# Altium schematic sheet PowerSchematic.SchDoc(PowerSchematic)
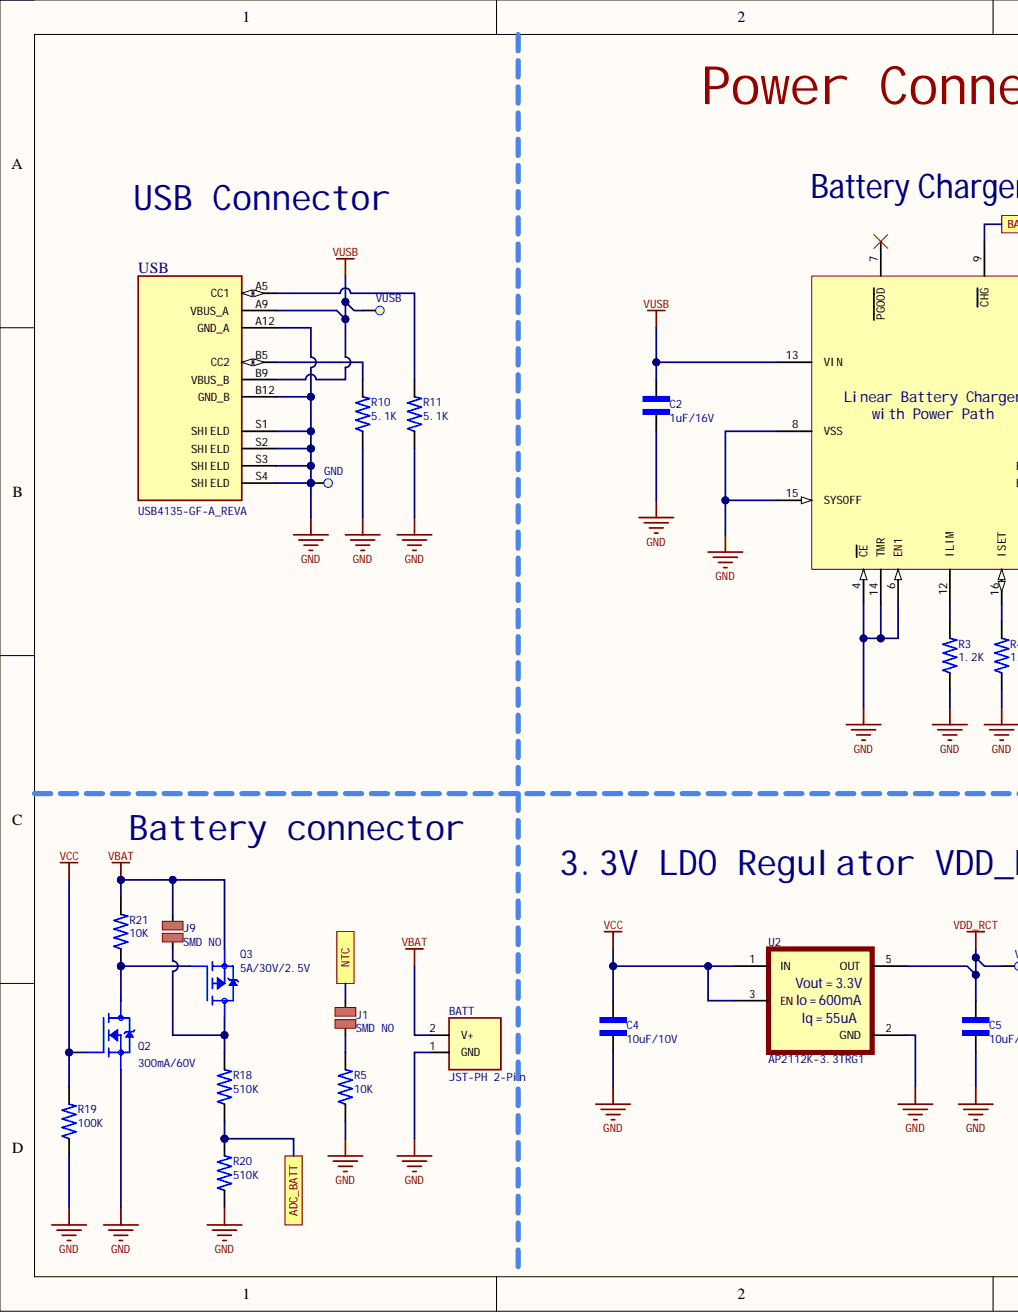
[diagram p0: PowerSchematic.SchDoc, left]
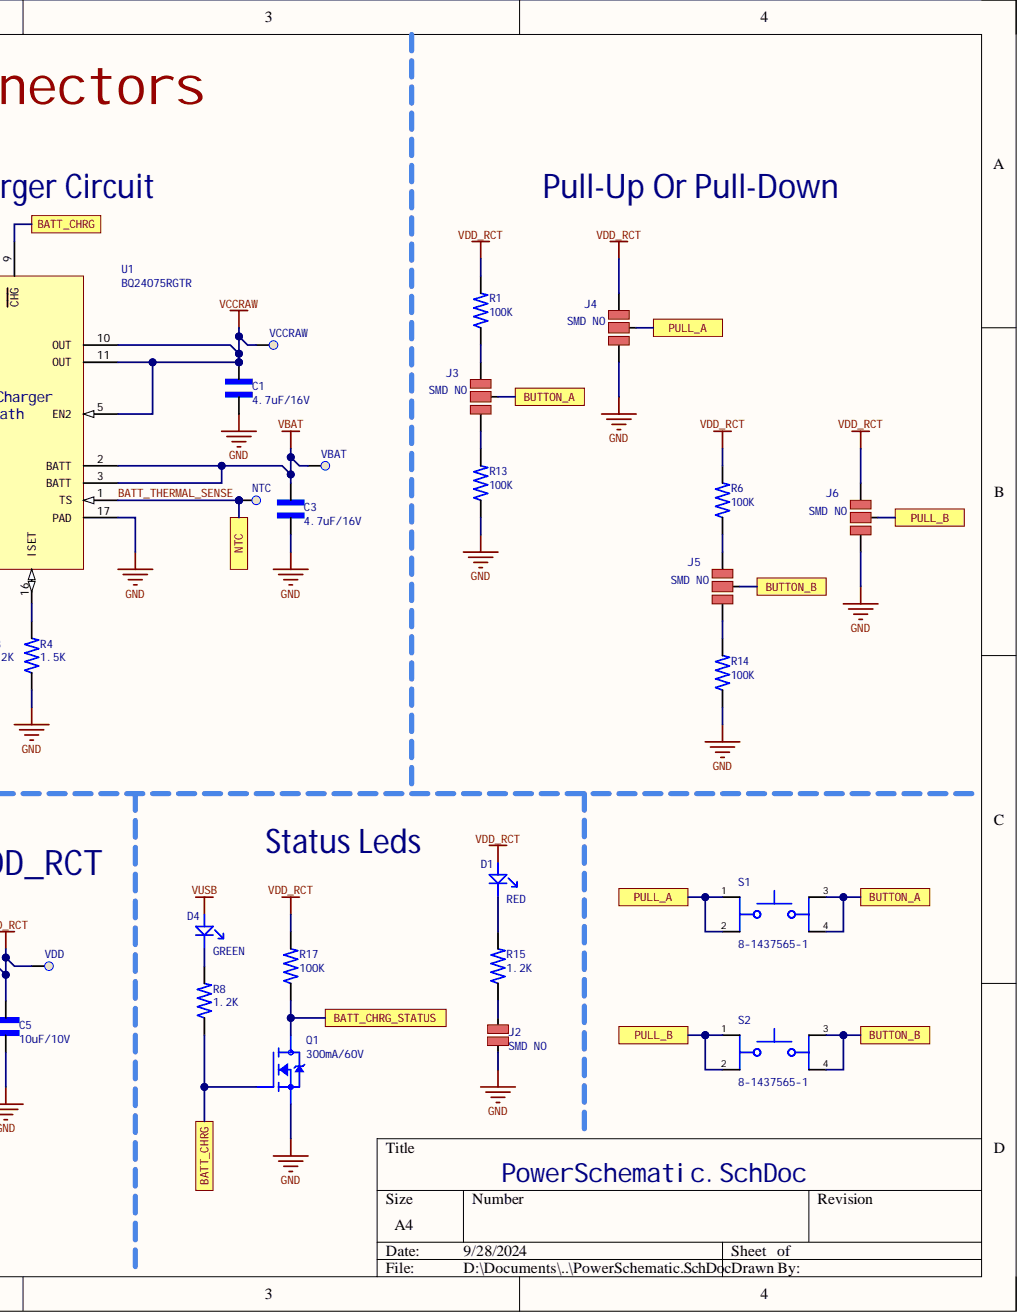
[diagram p1: PowerSchematic.SchDoc, right]

## Parts
BATT  JST-PH 2-Pin  [JST_B2B-PH-K-S(LF)(SN)]
C1  4.7uF/16V  [C0603]
C2  1uF/16V  [C0603]
C3  4.7uF/16V  [C0603]
C4  10uF/10V  [C0603]
C5  10uF/10V  [C0603]
D1  RED  [LED 0603]
D4  GREEN  [LED 0603]
GND  Test point 1.2mm  [TEST_POINT_1.2MM]  (value not drawn)  (no BOM)
J1  SMD NO  [JUMPER_SMD_NO]
J2  SMD NO  [JUMPER_SMD_NO]
J3  SMD NO  [JUMPER_SMD_NO_2WAY]
J4  SMD NO  [JUMPER_SMD_NO_2WAY]
J5  SMD NO  [JUMPER_SMD_NO_2WAY]
J6  SMD NO  [JUMPER_SMD_NO_2WAY]
J9  SMD NO  [JUMPER_SMD_NO]
NTC  Test point 1.2mm  [TEST_POINT_1.2MM]  (value not drawn)  (no BOM)
Q1  300mA/60V  [SOT-23-3]
Q2  300mA/60V  [SOT-23-3]
Q3  5A/30V/2.5V  [SOT-23-3]
R1  100K  [R0603]
R3  1.2K  [R0603]
R4  1.5K  [R0603]
R5  10K  [R0603]
R6  100K  [R0603]
R8  1.2K  [R0603]
R10  5.1K  [R0603]
R11  5.1K  [R0603]
R13  100K  [R0603]
R14  100K  [R0603]
R15  1.2K  [R0603]
R17  100K  [R0603]
R18  510K  [R0603]
R19  100K  [R0603]
R20  510K  [R0603]
R21  10K  [R0603]
S1  8-1437565-1  [SW_8-1437565-1]
S2  8-1437565-1  [SW_8-1437565-1]
U1  BQ24075RGTR  [VQFN16]
U2  AP2112K-3.3TRG1  Max Output Current: 600mA  Output Voltage: 3.3V  Quiescent Current: 55uA  [SOT-25-5]
USB  USB4135-GF-A_REVA  [GCT_USB4135 16-pin]
VBAT  Test point 1.2mm  [TEST_POINT_1.2MM]  (value not drawn)  (no BOM)
VCCRAW  Test point 1.2mm  [TEST_POINT_1.2MM]  (value not drawn)  (no BOM)
VDD  Test point 1.2mm  [TEST_POINT_1.2MM]  (value not drawn)  (no BOM)
VUSB  Test point 1.2mm  [TEST_POINT_1.2MM]  (value not drawn)  (no BOM)

## Ports
ADC_BATT, BATT_CHRG, BATT_CHRG_STATUS, BUTTON_A, BUTTON_B, NTC, PULL_A, PULL_B

## Nets
GND: BATT.1 C1.2 C2.2 C3.2 C4.2 C5.2 GND.1 J2.2 J4.1 J6.1 Q1.2 Q2.2 R3.1 R4.1 R5.1 R10.1 R11.1 R13.1 R14.1 R19.1 R20.1 U1.4(CE) U1.6(EN1) U1.8(VSS) U1.14(TMR) U1.15(SYSOFF) U1.17(PAD) U2.2(GND) USB.A12(GND_A) USB.B12(GND_B) USB.S1(SHIELD) USB.S2(SHIELD) USB.S3(SHIELD) USB.S4(SHIELD)
VBAT: BATT.2 C3.1 J9.1 Q3.2 R21.2 U1.2(BATT) U1.3(BATT) VBAT.1
VCC: C4.1 Q2.1 R19.2 U2.1(IN) U2.3(EN)
VCCRAW: C1.1 U1.5(EN2) U1.10(OUT) U1.11(OUT) VCCRAW.1
VDD_RCT: C5.1 D1.1 J4.3 J6.3 R1.2 R6.2 R17.2 U2.5(OUT) VDD.1
VUSB: C2.1 D4.1 U1.13(VIN) USB.A9(VBUS_A) USB.B9(VBUS_B) VUSB.1
ADC_BATT: R18.1 R20.2 ; port ADC_BATT
BATT_CHRG: Q1.1 R8.1 U1.9(CHG) ; port BATT_CHRG
BATT_CHRG_STATUS: Q1.3 R17.1 ; port BATT_CHRG_STATUS
BATT_THERMAL_SENSE: J1.1 NTC.1 U1.1(TS) ; port NTC
BUTTON_A: J3.2 S1.3 S1.4 ; port BUTTON_A
BUTTON_B: J5.2 S2.3 S2.4 ; port BUTTON_B
PULL_A: J4.2 S1.1 S1.2 ; port PULL_A
PULL_B: J6.2 S2.1 S2.2 ; port PULL_B
NetD1_2: D1.2 R15.2
NetD4_2: D4.2 R8.2
NetJ1_2: J1.2 R5.2
NetJ2_1: J2.1 R15.1
NetJ3_1: J3.1 R13.2
NetJ3_3: J3.3 R1.1
NetJ5_1: J5.1 R14.2
NetJ5_3: J5.3 R6.1
NetJ9_2: J9.2 Q3.3 R18.2
NetQ2_3: Q2.3 Q3.1 R21.1
NetR3_2: R3.2 U1.12(ILIM)
NetR4_2: R4.2 U1.16(ISET)
NetR10_2: R10.2 USB.B5(CC2)
NetR11_2: R11.2 USB.A5(CC1)

Not connected: U1 7(PGOOD)

## Library data of the parts
BATT = JST-PH 2-Pin: JST (JAPAN SOLDERLESS TERMINALS) - B2B-PH-K-S(LF)(SN) - Pin Header, Top Entry, Wire-to-Board, 2 mm, 1 Rows, 2 Contacts, Through Hole, PH Series; Category: Connectors; Component Kind: Standard; Height: 0.35inch; Length: 0.2inch; Library Name: Connectors.SchLib; Manufacturer: JST; Manufacturer Part Number: B2B-PH-K-S(LF)(SN); Pricing 1: 20=0.03960, 200=0.03310, 600=0.02840, 2000=0.02160, 10000=0.02100, 20000=0.02070 (USD); RoHS: Yes; Stock 1: 3352380; Supplier 1: LCSC; Supplier Part Number 1: C131337; Weight: 0.0625lb; Width: 0.24inch
C1 C3 = C0603 4.7uF/16V: 0603 4.7 uF 16 V ±10% Tolerance X5R Multilayer Ceramic Capacitor; Manufacturer: Murata; Manufacturer Part Number: GRT188R61C475KE13D; Value: 4.7uF; Voltage Rating: 16V; Case Code (Imperial): 0603; Case Code (Metric): 1608; Case/Package: 0603; Category: Ceramic Capacitors; Component Kind: Standard; Length: 0.063inch; Library Name: Capacitors.SchLib; Max Operating Temperature: 85°C; Min Operating Temperature: -55°C; Mounting Technology: Surface Mount; Packaging: Cut Tape; Pricing 1: 1=0.16, 10=0.066, 50=0.066, 100=0.038, 1000=0.034, 10000=0.029 (USD); Ratings: AEC-Q200; RoHS: Yes; RoHS Compliant: Yes; Series: GRT; Stock 1: 39950; Supplier 1: Mouser; Supplier Part Number 1: 81-GRT188R61C475KE3D; Thickness: 0.035inch; Tolerance: 10%; Width: 0.031inch
C2 = C0603 1uF/16V X5R: MLCC 1uF 16V X5R 10% SMD 0603 85C Paper T/R; Manufacturer: Yageo; Manufacturer Part Number: CC0603KRX5R7BB105; Dissipation Factor: 7%; Capacitance: 1uF; Case Code (Imperial): 0603; Case Code (Metric): 1608; Case/Package: 0603; Category: Ceramic Capacitors; Component Kind: Standard; Construction: Flat; Depth: 0.8mm; Dielectric: X5R; Halogen Free: Halogen Free; Height: 0.8mm; Lead Free: Lead Free; Length: 1.6mm; Library Name: Capacitors.SchLib; Max Operating Temperature: 85°C; Min Operating Temperature: -55°C; Mount: Surface Mount; Number of Pins: 2; Package Quantity: 4000; Packaging: Tape and Reel; Pricing 1: 1=0.17000, 10=0.09100, 50=0.09100, 100=0.04100, 1000=0.03400, 10000=0.02100 (USD); Radiation Hardening: No; REACH SVHC: Unknown; Resistor Type: Ceramic; RoHS: Yes; RoHS Compliant: Yes; Series: CC; Stock 1: 726921; Supplier 1: Mouser; Supplier Part Number 1: 603-CC603KRX5R7BB105; Termination: SMD/SMT; Thickness: 0.035inch; Tolerance: 10%; Voltage Rating: 16V; Voltage Rating (DC): 16V; Width: 0.8mm
C4 C5 = C0603 10uF/10V: MLCC 10uF 10V X5R 20% 0603; Manufacturer: Samsung; Manufacturer Part Number: CL10A106MP8NNND; Category: Ceramic Capacitors; Component Kind: Standard; Library Name: Capacitors.SchLib; Pricing 1: 1=0.20000, 10=0.14000, 50=0.14000, 100=0.06600, 1000=0.03900, 10000=0.03000 (USD); RoHS: Yes; RoHS Compliant: Yes; Stock 1: 58774; Supplier 1: Mouser; Supplier 2: Mouser; Supplier Part Number 1: 187-CL10A106MP8NNND; Supplier Part Number 2: 963-LMK107BBJ106MAHT
D1 = LED 0603 RED: Red 625nm LED Indication - Discrete 2V 0603; Manufacturer: Wurth Electronics; Manufacturer Part Number: 150060RS55040; Dominant Wavelength: 624nm; Lens Size: 0.8mm; Lens Style: Flat; Lens Transparency: Diffused; Luminous Intensity: 90mcd; Test Current: 20mA; Viewing Angle: 140°; Case Code (Imperial): 0603; Case Code (Metric): 1608; Case/Package: 0603; Category: LEDs; Color: Red; Component Kind: Standard; Forward Voltage: 2V; Height: 0.5mm; Length: 1.6mm; Library Name: LED.SchLib; Mount: Surface Mount; Packaging: Tape and Reel; Pricing 1: 1=0.18000, 10=0.16200, 100=0.14700, 500=0.13200, 1000=0.11700, 2000=0.11700 (USD); RoHS: No; Stock 1: 8586; Supplier 1: Mouser; Supplier Part Number 1: 710-150060RS55040; Width: 0.8mm
D4 = LED 0603 GREEN: Green 575nm LED Indication - Discrete 2V 0603; Manufacturer: Wurth Electronics; Manufacturer Part Number: 150060VS55040; Dominant Wavelength: 573nm; Lens Size: 0.8mm; Lens Style: Flat; Lens Transparency: Diffused; Luminous Intensity: 60mcd; Test Current: 20mA; Viewing Angle: 140°; Case Code (Imperial): 0603; Case Code (Metric): 1608; Case/Package: 0603; Category: LEDs; Color: Green; Component Kind: Standard; Forward Voltage: 2V; Height: 0.5mm; Length: 1.6mm; Library Name: LED.SchLib; Mount: Surface Mount; Packaging: Cut Tape; Pricing 1: 1=0,18000, 10=0,16200, 50=0,16200, 100=0,14700, 1000=0,11700, 10000=0,11700 (USD); RoHS: No; Stock 1: 24940; Supplier 1: Mouser; Supplier Part Number 1: 710-150060VS55040; Width: 0.8mm
GND NTC VBAT VCCRAW VDD VUSB = Test point: SMD test point; Library Name: Connectors.SchLib
J1 J2 J9 = JUMPER_SMD_NO: Rule: Short-Circuit Constraint [Short Circuit - Allowed]; Component Kind: Net Tie; Library Name: Jumpers.SchLib
J3-J6 = JUMPER_SMD_NO_2WAY: Component Kind: Net Tie; Library Name: Jumpers.SchLib
Q1 Q2 = MOSFET N-CH 2N7002,215: Trans MOSFET N-CH 60V 0.3A 3-Pin TO-236AB T/R; Manufacturer: Nexperia; Category: MOSFETs; Component Kind: Standard; Library Name: DiscreteSemi.SchLib; Manufacturer Part Number: 2N7002,215; Pricing 1: 1=0.16000, 10=0.13800, 100=0.05900, 500=0.04800, 1000=0.03300, 3000=0.02500, 9000=0.02200, 24000=0.02000, 45000=0.01500 (USD); RoHS: No; Stock 1: 82531; Supplier 1: Mouser; Supplier Part Number 1: 771-2N7002-T/R
Q3 = MOSFET P-CH 30V/5A SI2347DS-T1-GE3: P-Channel 30 V 42 mOhm 22 nC Surface Mount Power Mosfet - SOT-23-3; Manufacturer: Vishay Semiconductors; Continuous Drain Current (ID): 3.8A; Drain to Source Breakdown Voltage: -30V; Drain to Source Resistance: 68mR; Drain to Source Voltage (Vdss): 30V; Element Configuration: Single; Fall Time: 9ns; Gate to Source Voltage (Vgs): 20V; Input Capacitance: 705pF; Max Power Dissipation: 1.7W; Number of Elements: 1; Rds On Max: 42mR; Rise Time: 6ns; Threshold Voltage: -2.5V; Turn-Off Delay Time: 19ns; Case/Package: SOT-23-3; Category: MOSFETs; Component Kind: Standard; Contact Plating: Tin,Matte; Lead Free: Lead Free; Library Name: DiscreteSemi.SchLib; Manufacturer Part Number: Si2347DS-T1-GE3; Max Operating Temperature: 150°C; Min Operating Temperature: -55°C; Mount: Surface Mount; Number of Pins: 3; Package Quantity: 3000; Packaging: Tape and Reel; Polarity: P-CHANNEL; Power Dissipation: 1.7W; Pricing 1: 1=0.41000, 10=0.27600, 50=0.27600, 100=0.14500, 1000=0.10000, 10000=0.07700 (USD); Radiation Hardening: No; REACH SVHC: No SVHC; RoHS: Yes; RoHS Compliant: Yes; Series: TrenchFET®; Stock 1: 90370; Supplier 1: Mouser; Supplier Part Number 1: 78-SI2347DS-T1-GE3
R1 R6 R13 R14 R17 R19 = R0603 100K: RES 0603 100K OHM 1% 1/10W; Manufacturer: Yageo; Manufacturer Part Number: RC0603FR-07100KL; Power Rating: 100mW; Resistance: 100kR; Temperature Coefficient: 100ppm/°C; Voltage Rating: 50V; Working Voltage: 75V; Case Code (Imperial): 0603; Case Code (Metric): 1608; Case/Package: 0603; Category: Chip SMD Resistors; Component Kind: Standard; Depth: 0.8mm; Height: 0.45mm; Lead Free: Lead Free; Length: 1.6mm; Library Name: Resistors.SchLib; Max Operating Temperature: 155°C; Military Standard: Not; Min Operating Temperature: -55°C; Number of Pins: 2; Number of Terminations: 2; Packaging: Tape and Reel; Pricing 1: 1=0.10000, 10=0.02100, 100=0.00900, 1000=0.00400, 5000=0.00300, 10000=0.00200 (USD); REACH SVHC: No SVHC; Resistor Type: Thick Film; RoHS: Yes; Stock 1: 4536807; Supplier 1: Mouser; Supplier Part Number 1: 603-RC0603FR-07100KL; Termination: SMD/SMT; Tolerance: 1%; Voltage Rating (DC): 50V; Width: 0.8mm
R3 R8 R15 = R0603 1.2K: RES 0603 1.2K OHM 1% 1/10W; Manufacturer: Yageo; Manufacturer Part Number: RC0603FR-071K2L; Max Power Dissipation: 100mW; Resistance: 1.2kR; Temperature Coefficient: 100ppm/°C; Voltage Rating: 50V; Case Code (Imperial): 0603; Case Code (Metric): 1608; Case/Package: 0603; Category: Chip SMD Resistors; Component Kind: Standard; ComponentLink1Description: http://www.farnell.com/datasheets/443971.pdf?src-supplier=Farnell; ComponentLink1URL: http://www.farnell.com/datasheets/443971.pdf?src-supplier=Farnell; ComponentLink2Description: http://www.farnell.com/datasheets/1868787.pdf?src-supplier=Farnell; ComponentLink2URL: http://www.farnell.com/datasheets/1868787.pdf?src-supplier=Farnell; ComponentLink3Description: http://www.farnell.com/datasheets/2167052.pdf?src-supplier=Farnell; ComponentLink3URL: http://www.farnell.com/datasheets/2167052.pdf?src-supplier=Farnell; ComponentLink4Description: http://www.mouser.com/ds/2/447/RC0603-272842.pdf?src-supplier=Mouser; ComponentLink4URL: http://www.mouser.com/ds/2/447/RC0603-272842.pdf?src-supplier=Mouser; ComponentLink5Description: http://components.arrow.com/part/dataview/0323_00047720?src-supplier=Arrow+Europe; ComponentLink5URL: http://components.arrow.com/part/dataview/0323_00047720?src-supplier=Arrow+Europe; ComponentLink6Description: http://www.farnell.com/datasheets/443971.pdf?src-supplier=Element14; ComponentLink6URL: http://www.farnell.com/datasheets/443971.pdf?src-supplier=Element14; ComponentLink7Description: http://www.farnell.com/datasheets/2167052.pdf?src-supplier=Newark; ComponentLink7URL: http://www.farnell.com/datasheets/2167052.pdf?src-supplier=Newark; ComponentLink8Description: http://www.farnell.com/datasheets/1868787.pdf?src-supplier=Element14; ComponentLink8URL: http://www.farnell.com/datasheets/1868787.pdf?src-supplier=Element14; ComponentLink9Description: http://www.farnell.com/datasheets/2167052.pdf?src-supplier=Element14; ComponentLink9URL: http://www.farnell.com/datasheets/2167052.pdf?src-supplier=Element14; ComponentLink10Description: http://www.yageo.com/documents/recent/PYu-RC0603_51_RoHS_L_7.pdf?src-supplier=Future+Electronics; ComponentLink10URL: http://www.yageo.com/documents/recent/PYu-RC0603_51_RoHS_L_7.pdf?src-supplier=Future+Electronics; ComponentLink11Description: https://www.verical.com/server-webapp/html/getDatasheet?partID=326670&src-supplier=Verical; ComponentLink11URL: https://www.verical.com/server-webapp/html/getDatasheet?partID=326670&src-supplier=Verical; ComponentLink12Description: http://www.farnell.com/datasheets/1868787.pdf?src-supplier=Newark; ComponentLink12URL: http://www.farnell.com/datasheets/1868787.pdf?src-supplier=Newark; ComponentLink13Description: http://www.yageo.com/documents/recent/PYu-RC_Group_51_RoHS_L_9.pdf?src-supplier=Digi-Key; ComponentLink13URL: http://www.yageo.com/documents/recent/PYu-RC_Group_51_RoHS_L_9.pdf?src-supplier=Digi-Key; ComponentLink14Description: http://www.yageo.com/documents/recent/PYu-RC_Group_51_RoHS_L_10.pdf?src-supplier=Digi-Key; ComponentLink14URL: http://www.yageo.com/documents/recent/PYu-RC_Group_51_RoHS_L_10.pdf?src-supplier=Digi-Key; Construction: Rectangular; Depth: 0.8mm; Features: Moisture Resistant; Height: 0.45mm; Lead Free: Lead Free; Length: 1.6mm; Library Name: Resistors.SchLib; Max Operating Temperature: 155°C; Military Standard: Not; Min Operating Temperature: -55°C; Number of Pins: 2; Number of Terminations: 2; Packaging: Tape and Reel; Power Rating: 100mW; Pricing 1: 1=0.10000, 10=0.02400, 50=0.02400, 100=0.01000, 1000=0.00400, 10000=0.00300 (USD); REACH SVHC: No SVHC; Resistance Tolerance: 1%; Resistor Type: Thick Film; RoHS: Yes; RoHS Compliant: Yes; Series: RC; Size Code: 0603; Stock 1: 27884; Supplier 1: Mouser; Supplier Part Number 1: 603-RC0603FR-071K2L; Termination: SMD/SMT; Tolerance: 1%; Voltage Rating (DC): 50V; Width: 0.8mm
R4 = R0603 1.5K: RES 0603 1.5K OHM 0.5% 1/10W; Manufacturer: Yageo; Manufacturer Part Number: RC0603FR-071K5L; Max Power Dissipation: 100mW; Resistance: 1.5kR; Temperature Coefficient: 100ppm/°C; Tolerance: 1%; Voltage Rating: 50V; Case Code (Imperial): 0603; Case Code (Metric): 1608; Case/Package: 0603; Category: Chip SMD Resistors; Component Kind: Standard; Depth: 0.8mm; Features: Moisture Resistant; Height: 0.022inch; Lead Free: Lead Free; Length: 0.063inch; Library Name: Resistors.SchLib; Max Operating Temperature: 155°C; Military Standard: Not; Min Operating Temperature: -55°C; Number of Pins: 2; Number of Terminations: 2; Package Quantity: 1; Packaging: Tape and Reel; Power Rating: 100mW; Pricing 1: 1=0.10000, 10=0.01800, 50=0.01800, 100=0.00600, 1000=0.00500, 10000=0.00300 (USD); REACH SVHC: No SVHC; Resistor Type: Thick Film; RoHS: Yes; Stock 1: 312486; Supplier 1: Mouser; Supplier Part Number 1: 603-RC0603FR-071K5L; Termination: SMD/SMT; Voltage Rating (DC): 50V; Width: 0.031inch
R5 R21 = R0603 10K: SMD Chip Resistor, 10 kOhm, ± 1%, 100 mW, 0603 [1608 Metric], Thick Film, Anti-Sulfur; Manufacturer: Yageo; Manufacturer Part Number: AF0603FR-0710KL; Max Power Dissipation: 100mW; Temperature Coefficient: 100ppm/°C; Value: 10kR; Voltage Rating: 50V; Case Code (Imperial): 0603; Case Code (Metric): 1608; Case/Package: 0603; Category: Chip SMD Resistors; Component Kind: Standard; Features: Moisture Resistant,Anti-Sulfur; Height: 0.022inch; Lead Free: 5697LeadFree; Length: 0.063inch; Library Name: Resistors.SchLib; Max Operating Temperature: 155°C; Min Operating Temperature: -55°C; Number of Terminations: 2; Package Quantity: 1; Packaging: Tape and Reel; Power Rating: 100mW; Pricing 3: 5000=0.00223, 10000=0.00219, 20000=0.00213 (USD); RoHS: Yes; RoHS Compliant: Yes; Series: AF; Stock 3: 5000; Supplier 1: Mouser; Supplier 2: Mouser; Supplier Part Number 1: 603-AF0603FR-0710KL; Supplier Part Number 2: 667-ERJ-3EKF1002V; Termination: SMD/SMT; Tolerance: 1%; Width: 0.031inch
R10 R11 = R0603 5.1K: RES 0603 5.1K OHM 1% 1/10W; Manufacturer: Yageo; Manufacturer Part Number: RC0603FR-075K1L; Max Power Dissipation: 100mW; Resistance: 5.1kR; Temperature Coefficient: 100ppm/°C; Voltage Rating: 50V; Case Code (Imperial): 0603; Case Code (Metric): 1608; Case/Package: 0603; Category: Chip SMD Resistors; Component Kind: Standard; Depth: 0.8mm; Features: Moisture Resistant; Height: 0.022inch; Lead Free: Lead Free; Length: 0.063inch; Library Name: Resistors.SchLib; Max Operating Temperature: 155°C; Military Standard: Not; Min Operating Temperature: -55°C; Number of Pins: 2; Number of Terminations: 2; Package Quantity: 1; Packaging: Tape and Reel; Power Rating: 100mW; REACH SVHC: No SVHC; Resistor Type: Thick Film; RoHS: Yes; Stock 1: 557916; Supplier 1: Mouser; Supplier Part Number 1: 603-RC0603FR-075K1L; Termination: SMD/SMT; Tolerance: 1%; Voltage Rating (DC): 50V; Width: 0.031inch
R18 R20 = R0603 510K: RES 0603 510K OHM 1% 1/10W; Manufacturer: TE Connectivity; Manufacturer Part Number: CPF0603B510KE; Max Power Dissipation: 63mW; Resistance: 510kR; Temperature Coefficient: 25ppm/°C; Tolerance: 0.1%; Case Code (Imperial): 0603; Case Code (Metric): 1608; Case/Package: 0603; Category: Uncategorized; Component Kind: Standard; Library Name: Resistors.SchLib; Max Operating Temperature: 155°C; Min Operating Temperature: -55°C; Number of Terminations: 2; Packaging: Cut Tape; Pricing 1: 1=0.49, 10=0.329, 50=0.329, 100=0.187, 1000=0.1, 10000=0.092 (USD); RoHS: Yes; RoHS Compliant: Yes; Series: CPF, Neohm; Stock 1: 16357; Supplier 1: Mouser; Supplier Part Number 1: 279-CPF0603B510KE
S1 S2 = 8-1437565-1: Switch Tactile OFF (ON) SPST Round Button Gull Wing 0.05A 24VDC 1.57N SMD T/R; Manufacturer: TE Connectivity Alcoswitch; Actuator Length: 0.4mm; Actuator Material: Brass; Actuator Type: Standard; Contact Current Rating: 20mA; Contact Resistance: 100mR; Electrical Life: 500000Cycles; Illumination Type: Non-Illuminated; Max Voltage Rating (DC): 15V; Number of Positions: 2NUMBER; Switch Function: Off-Mom; Switch Travel: 0.25mm; Case/Package: SMD/SMT; Category: Pushbutton Switches; Component Kind: Standard; ComponentLink1Description: https://datasheet.ciiva.com/8082/eng-cs-1308111-1-switches-core-program-catalog-0308-8082416.pdf?src-supplier=Avnet; ComponentLink1URL: https://datasheet.ciiva.com/8082/eng-cs-1308111-1-switches-core-program-catalog-0308-8082416.pdf?src-supplier=Avnet; Contact Material: Copper Alloy; Contact Plating: Silver; ELV: 5681ELVCompliant; Height: 1.7mm; Lead Free: 5697LeadFree; Library Name: Switches.SchLib; Manufacturer Part Number: 8-1437565-1; Max Operating Temperature: 85°C; Mechanical Life: 500000Cycles; Min Operating Temperature: -35°C; Mount: Surface Mount; Operating Force: 1.57N; Orientation: Straight; Package Quantity: 4000; Packaging: Tape and Reel; Pricing 1: 1=0.72, 10=0.72, 50=0.72, 100=0.697, 1000=0.567, 10000=0.371 (USD); Radiation Hardening: No; RoHS: Yes; RoHS Compliant: Yes; Series: FSMCT; Stock 1: 20150; Supplier 1: Mouser; Supplier Part Number 1: 506-8-1437565-1; Termination: Gull Wing; Throw Configuration: SPST; Type: Switch
U1 = BQ24075RGTR: IC LI-ION CHARGE MGMT 16-QFN; Manufacturer: Texas Instruments; Battery Chemistry: LITHIUM-ION; Battery Pack Voltage: 4.2V; Fault Protection: Over Voltage,Over Temperature,Short Circuit,Reverse Current; Interface: USB; Max Charge Current: 1.5A; Max Supply Voltage: 6.4V; Min Input Voltage: 4.3V; Min Supply Voltage: 4.35V; Number of Cells: 1; Case/Package: VQFN; Category: Battery Management; Component Kind: Standard; ComponentLink1Description: https://datasheet.ciiva.com/15114/getdatasheetpartid-471953-15114762.pdf?src-supplier=Verical; ComponentLink1URL: https://datasheet.ciiva.com/15114/getdatasheetpartid-471953-15114762.pdf?src-supplier=Verical; ComponentLink2Description: https://datasheet.ciiva.com/3214/bq24079-3214043.pdf?src-supplier=Avnet; ComponentLink2URL: https://datasheet.ciiva.com/3214/bq24079-3214043.pdf?src-supplier=Avnet; ComponentLink3Description: https://datasheet.ciiva.com/1934/bq24072-1934514.pdf?src-supplier=Digi-Key; ComponentLink3URL: https://datasheet.ciiva.com/1934/bq24072-1934514.pdf?src-supplier=Digi-Key; Contact Plating: Gold; Lead Free: Lead Free; Library Name: IC Power.SchLib; Manufacturer Part Number: BQ24075RGTR; Max Operating Temperature: 125°C; Min Operating Temperature: -40°C; Number of Pins: 16; Output Current: 1.5A; Output Voltage: 4.2V; Package Quantity: 3000; Packaging: Tape and Reel; Pricing 1: 1=2.52000, 10=2.27000, 50=2.14000, 100=1.82000, 1000=1.24000, 10000=1.24000 (USD); Radiation Hardening: No; RoHS: Yes; RoHS Compliant: Yes; Series: -; Stock 1: 21933; Supplier 1: Mouser; Supplier 2: Digi-Key; Supplier 3: Digi-Key; Supplier 4: Arrow Electronics; Supplier Part Number 1: 595-BQ24075RGTR; Supplier Part Number 2: 296-38874-1-ND; Supplier Part Number 3: 296-38874-2-ND; Supplier Part Number 4: BQ24075RGTR; Weight: 0.000808oz
U2 = AP2112K-3.3TRG1: IC REG LINEAR 3.3V 600MA SOT25; Manufacturer: Diodes; Accuracy: 1.5%; Dropout Voltage: 250mV; Max Input Voltage: 6V; Min Input Voltage: 2.5V; Number of Outputs: 1; Output Type: Fixed; Polarity: Positive; Case/Package: SOT-23-5; Category: Voltage Regulators - Linear; Component Kind: Standard; ComponentLink1Description: https://datasheet.ciiva.com/29569/ap2112-29569917.pdf?src-supplier=Digi-Key; ComponentLink1URL: https://datasheet.ciiva.com/29569/ap2112-29569917.pdf?src-supplier=Digi-Key; ComponentLink2Description: https://datasheet.ciiva.com/29569/ap2112-29569918.pdf?src-supplier=Digi-Key; ComponentLink2URL: https://datasheet.ciiva.com/29569/ap2112-29569918.pdf?src-supplier=Digi-Key; ComponentLink3Description: https://datasheet.ciiva.com/29569/ap2112-29569919.pdf?src-supplier=Digi-Key; ComponentLink3URL: https://datasheet.ciiva.com/29569/ap2112-29569919.pdf?src-supplier=Digi-Key; ComponentLink4Description: https://datasheet.ciiva.com/30016/ap2112-30016792.pdf?src-supplier=Avnet; ComponentLink4URL: https://datasheet.ciiva.com/30016/ap2112-30016792.pdf?src-supplier=Avnet; Height: 1.3mm; Lead Free: Lead Free; Length: 3.1mm; Library Name: IC Power.SchLib; Manufacturer Part Number: AP2112K-3.3TRG1; Max Operating Temperature: 85°C; Min Operating Temperature: -40°C; Mount: Surface Mount; Number of Pins: 5; Number of Regulators: 1; Output Current: 600mA; Package Quantity: 1; Packaging: Tape and Reel; Pricing 1: 1=0.47000, 10=0.31700, 50=0.31700, 100=0.15000, 1000=0.11600, 10000=0.08900 (USD); RoHS: Yes; RoHS Compliant: Yes; Stock 1: 4864; Supplier 1: Mouser; Supplier Part Number 1: 621-AP2112K-3.3TRG1; Width: 1.7mm
USB = USB4135-GF-A_REVA: Usb C Rec Gf Ra 6P SMT & SMT She; Manufacturer 1: Global Connector Technology; Category: Connectors; Component Kind: Standard; Library Name: Connectors.SchLib; Manufacturer Part Number 1: USB4135-GF-A; Pricing 1: 1=0.67300, 50=0.59700, 100=0.56000, 250=0.52200, 500=0.46600, 1000=0.43300, 1500=0.40000, 3000=0.33600 (USD); RoHS: No; Stock 1: 1196; Supplier 1: Mouser; Supplier Part Number 1: 640-USB4135-GF-A
